# Altium schematic sheet Schematic.SchDoc
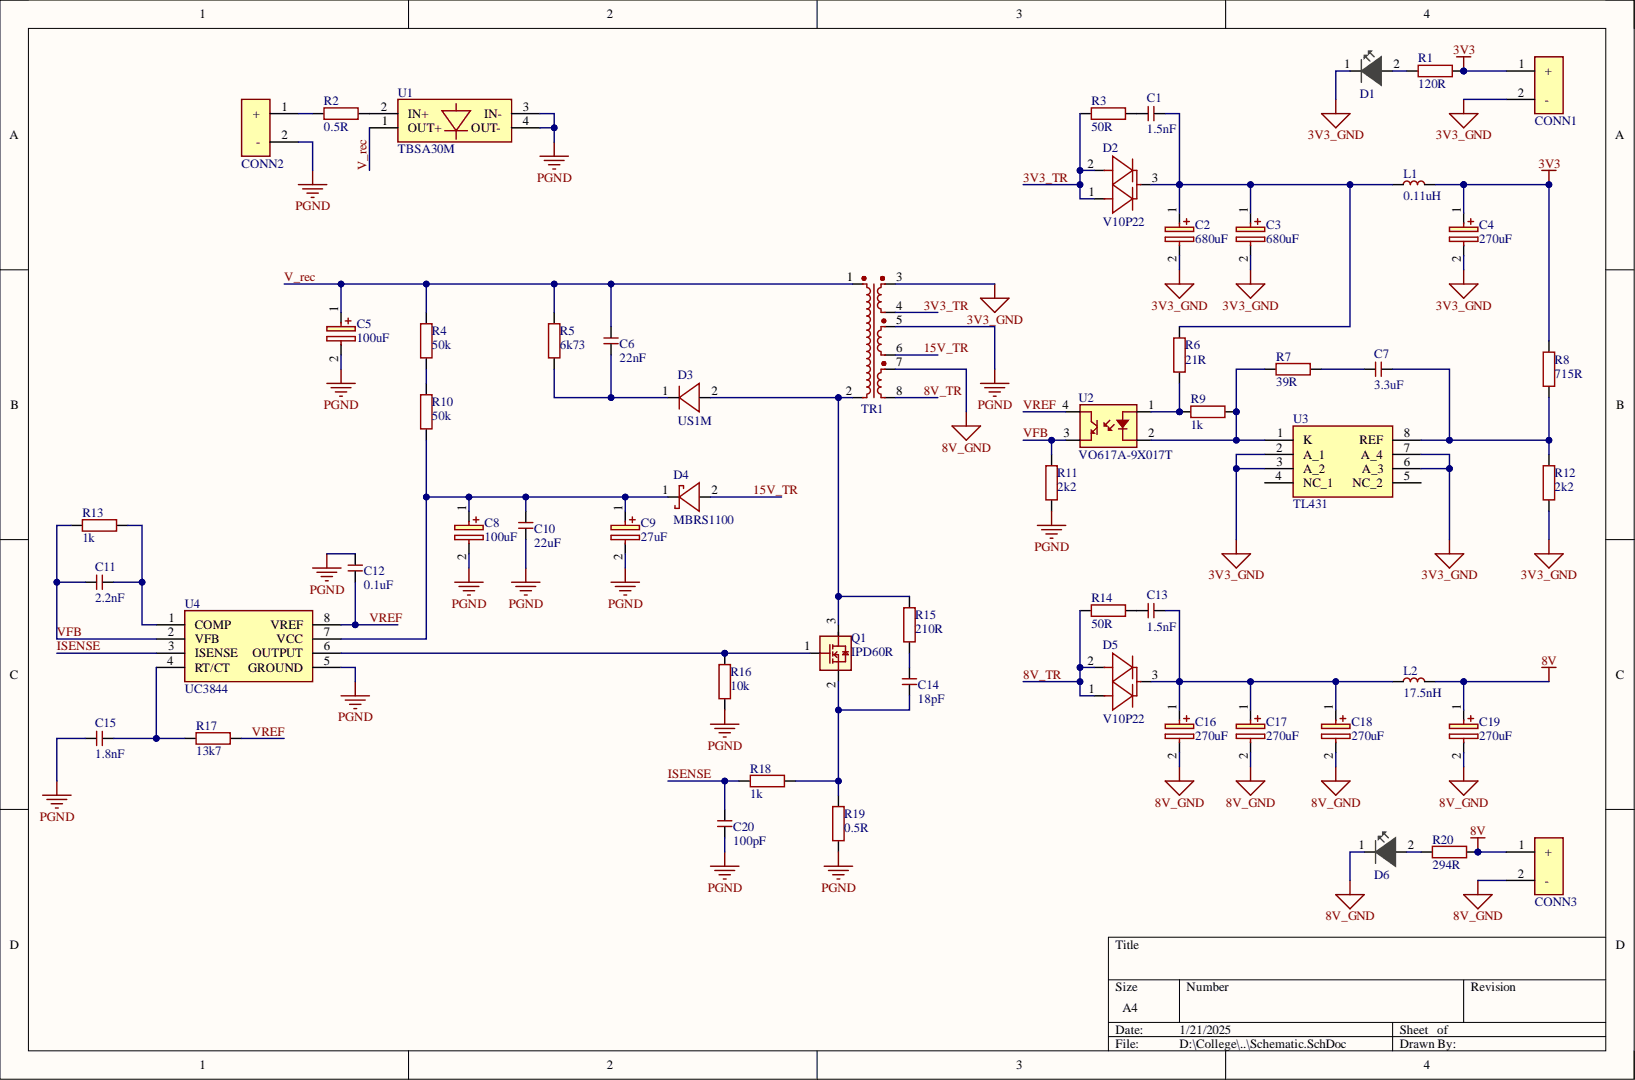
[diagram p0: Schematic.SchDoc]

## Parts
C1  1.5nF  [C0805]
C2  680uF  [16SVPT560M]
C3  680uF  [16SVPT560M]
C4  270uF  [16SVPG270M]
C5  100uF  [CAPPRD750W80D1625H3200]
C6  22nF  [C1206]
C7  3.3uF  [C1206]
C8  100uF  [CAPAE660X600N]
C9  27uF  [CAPAE660X610N]
C10  22uF  [C1210]
C11  2.2nF  [C0805]
C12  0.1uF  [C0603]
C13  1.5nF  [C0805]
C14  18pF  [C0805]
C15  1.8nF  [C0805]
C16  270uF  [16SVPG270M]
C17  270uF  [16SVPG270M]
C18  270uF  [16SVPG270M]
C19  270uF  [16SVPG270M]
C20  100pF  [C0805]
CONN1  CONNECTOR  [1760510000]  (value not drawn)
CONN2  CONNECTOR  [1760510000]  (value not drawn)
CONN3  CONNECTOR  [1760510000]  (value not drawn)
D1  150060VS75000  [LED-0603]  (value not drawn)
D2  V10P22  [TO-277A]
D3  US1M  [DIOM5127X229N]
D4  MBRS1100  [DIOM5436X244N]
D5  V10P22  [TO-277A]
D6  150060VS75000  [LED-0603]  (value not drawn)
L1  0.11uH  [L0805]
L2  17.5nH  [AIAC2712C17N5JT]
Q1  IPD60R  [DPAK]
R1  120R  [R0805]
R2  0.5R  [R1206]
R3  50R  [R0603]
R4  50k  [R1206]
R5  6k73  [R0805]
R6  21R  [R0805]
R7  39R  [R0805]
R8  715R  [R0805]
R9  1k  [R0805]
R10  50k  [R1206]
R11  2k2  [R0805]
R12  2k2  [R0805]
R13  1k  [R0805]
R14  50R  [R0603]
R15  210R  [R1206]
R16  10k  [R0805]
R17  13k7  [R0805]
R18  1k  [R0805]
R19  0.5R  [R1206]
R20  294R  [R0805]
TR1  B66417G0000X149  [B66418W1008D001]  (value not drawn)
U1  TBSA30M  [TBSA30MTP]
U2  VO617A-9X017T  [VO617A-9X017T]
U3  TL431  [SOIC127P600X175-8N]
U4  UC3844  [SOIC127P600X175-8N]

## Nets
3V3: C4.1 CONN1.1 L1.2 R1.2 R8.2
3V3_GND: C2.2 C3.2 C4.2 CONN1.2 D1.1 R12.1 TR1.3 U3.2(A_1) U3.3(A_2) U3.6(A_3) U3.7(A_4)
8V: C19.1 CONN3.1 L2.2 R20.2
8V_GND: C16.2 C17.2 C18.2 C19.2 CONN3.2 D6.1 TR1.7
PGND: C5.2 C8.2 C9.2 C10.1 C12.2 C15.1 C20.1 CONN2.2 R11.1 R16.1 R19.1 TR1.5 U1.3(IN-) U1.4(OUT-) U4.5(GROUND)
3V3_TR: D2.1 D2.2 R3.1 TR1.4
8V_TR: D5.1 D5.2 R14.1 TR1.8
15V_TR: D4.2 TR1.6
ISENSE: C20.2 R18.1 U4.3(ISENSE)
V_rec: C5.1 C6.2 R4.2 R5.2 TR1.1 U1.1(OUT+)
VFB: C11.1 R11.2 R13.1 U2.3 U4.2(VFB)
VREF: C12.1 R17.2 U2.4 U4.8(VREF)
NetC1_1: C1.1 R3.2
NetC1_2: C1.2 C2.1 C3.1 D2.3 L1.1 R6.2
NetC6_1: C6.1 D3.1 R5.1
NetC7_1: C7.1 R7.2
NetC7_2: C7.2 R8.1 R12.2 U3.8(REF)
NetC8_1: C8.1 C9.1 C10.2 D4.1 R10.1 U4.7(VCC)
NetC11_2: C11.2 R13.2 U4.1(COMP)
NetC13_1: C13.1 R14.2
NetC13_2: C13.2 C16.1 C17.1 C18.1 D5.3 L2.1
NetC14_1: C14.1 Q1.2 R18.2 R19.2
NetC14_2: C14.2 R15.1
NetC15_2: C15.2 R17.1 U4.4(RT/CT)
NetCONN2_1: CONN2.1 R2.1
NetD1_2: D1.2 R1.1
NetD3_2: D3.2 Q1.3 R15.2 TR1.2
NetD6_2: D6.2 R20.1
NetQ1_1: Q1.1 R16.2 U4.6(OUTPUT)
NetR2_2: R2.2 U1.2(IN+)
NetR4_1: R4.1 R10.2
NetR6_1: R6.1 R9.1 U2.1
NetR7_1: R7.1 R9.2 U2.2 U3.1(K)

Not connected: U3 4(NC_1) 5(NC_2)
